# Altium schematic sheet Precise_Resistors.SchDoc
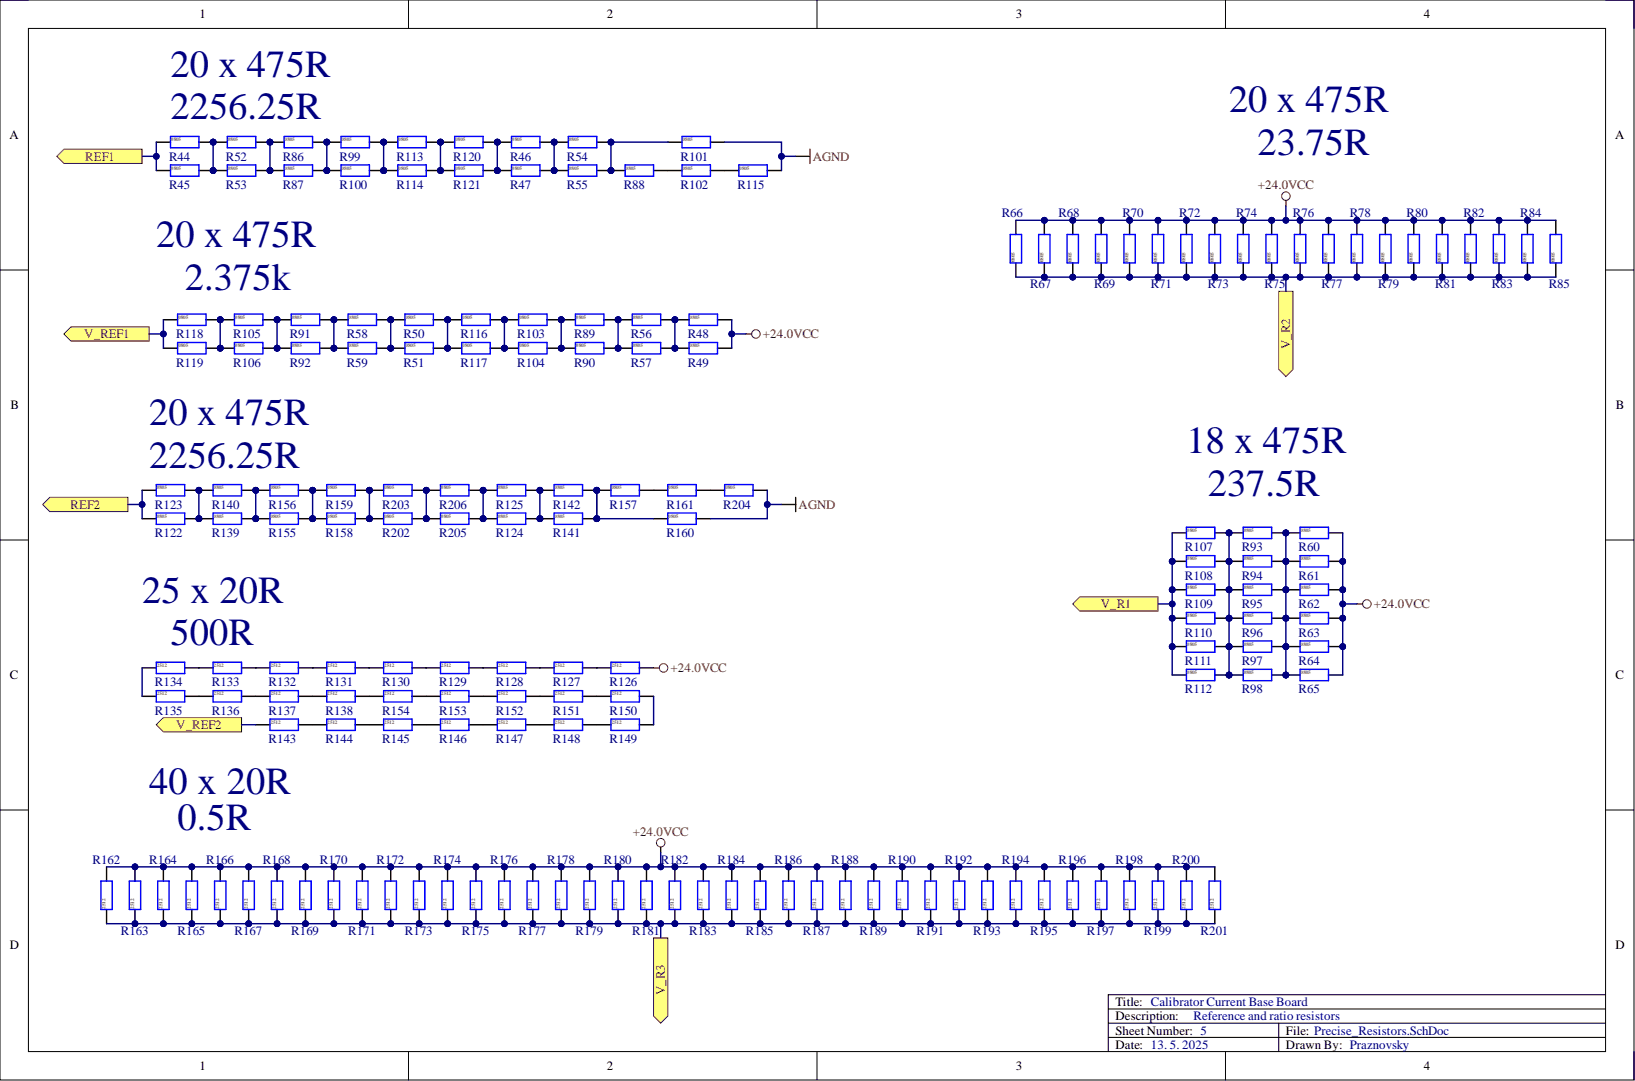
[diagram p0: Precise_Resistors.SchDoc]

## Parts
R44  Y4022475R000F9R  [R0805]  (value not drawn)
R45  Y4022475R000F9R  [R0805]  (value not drawn)
R46  Y4022475R000F9R  [R0805]  (value not drawn)
R47  Y4022475R000F9R  [R0805]  (value not drawn)
R48  Y4022475R000F9R  [R0805]  (value not drawn)
R49  Y4022475R000F9R  [R0805]  (value not drawn)
R50  Y4022475R000F9R  [R0805]  (value not drawn)
R51  Y4022475R000F9R  [R0805]  (value not drawn)
R52  Y4022475R000F9R  [R0805]  (value not drawn)
R53  Y4022475R000F9R  [R0805]  (value not drawn)
R54  Y4022475R000F9R  [R0805]  (value not drawn)
R55  Y4022475R000F9R  [R0805]  (value not drawn)
R56  Y4022475R000F9R  [R0805]  (value not drawn)
R57  Y4022475R000F9R  [R0805]  (value not drawn)
R58  Y4022475R000F9R  [R0805]  (value not drawn)
R59  Y4022475R000F9R  [R0805]  (value not drawn)
R60  Y4022475R000F9R  [R0805]  (value not drawn)
R61  Y4022475R000F9R  [R0805]  (value not drawn)
R62  Y4022475R000F9R  [R0805]  (value not drawn)
R63  Y4022475R000F9R  [R0805]  (value not drawn)
R64  Y4022475R000F9R  [R0805]  (value not drawn)
R65  Y4022475R000F9R  [R0805]  (value not drawn)
R66  Y4022475R000F9R  [R0805]  (value not drawn)
R67  Y4022475R000F9R  [R0805]  (value not drawn)
R68  Y4022475R000F9R  [R0805]  (value not drawn)
R69  Y4022475R000F9R  [R0805]  (value not drawn)
R70  Y4022475R000F9R  [R0805]  (value not drawn)
R71  Y4022475R000F9R  [R0805]  (value not drawn)
R72  Y4022475R000F9R  [R0805]  (value not drawn)
R73  Y4022475R000F9R  [R0805]  (value not drawn)
R74  Y4022475R000F9R  [R0805]  (value not drawn)
R75  Y4022475R000F9R  [R0805]  (value not drawn)
R76  Y4022475R000F9R  [R0805]  (value not drawn)
R77  Y4022475R000F9R  [R0805]  (value not drawn)
R78  Y4022475R000F9R  [R0805]  (value not drawn)
R79  Y4022475R000F9R  [R0805]  (value not drawn)
R80  Y4022475R000F9R  [R0805]  (value not drawn)
R81  Y4022475R000F9R  [R0805]  (value not drawn)
R82  Y4022475R000F9R  [R0805]  (value not drawn)
R83  Y4022475R000F9R  [R0805]  (value not drawn)
R84  Y4022475R000F9R  [R0805]  (value not drawn)
R85  Y4022475R000F9R  [R0805]  (value not drawn)
R86  Y4022475R000F9R  [R0805]  (value not drawn)
R87  Y4022475R000F9R  [R0805]  (value not drawn)
R88  Y4022475R000F9R  [R0805]  (value not drawn)
R89  Y4022475R000F9R  [R0805]  (value not drawn)
R90  Y4022475R000F9R  [R0805]  (value not drawn)
R91  Y4022475R000F9R  [R0805]  (value not drawn)
R92  Y4022475R000F9R  [R0805]  (value not drawn)
R93  Y4022475R000F9R  [R0805]  (value not drawn)
R94  Y4022475R000F9R  [R0805]  (value not drawn)
R95  Y4022475R000F9R  [R0805]  (value not drawn)
R96  Y4022475R000F9R  [R0805]  (value not drawn)
R97  Y4022475R000F9R  [R0805]  (value not drawn)
R98  Y4022475R000F9R  [R0805]  (value not drawn)
R99  Y4022475R000F9R  [R0805]  (value not drawn)
R100  Y4022475R000F9R  [R0805]  (value not drawn)
R101  Y4022475R000F9R  [R0805]  (value not drawn)
R102  Y4022475R000F9R  [R0805]  (value not drawn)
R103  Y4022475R000F9R  [R0805]  (value not drawn)
R104  Y4022475R000F9R  [R0805]  (value not drawn)
R105  Y4022475R000F9R  [R0805]  (value not drawn)
R106  Y4022475R000F9R  [R0805]  (value not drawn)
R107  Y4022475R000F9R  [R0805]  (value not drawn)
R108  Y4022475R000F9R  [R0805]  (value not drawn)
R109  Y4022475R000F9R  [R0805]  (value not drawn)
R110  Y4022475R000F9R  [R0805]  (value not drawn)
R111  Y4022475R000F9R  [R0805]  (value not drawn)
R112  Y4022475R000F9R  [R0805]  (value not drawn)
R113  Y4022475R000F9R  [R0805]  (value not drawn)
R114  Y4022475R000F9R  [R0805]  (value not drawn)
R115  Y4022475R000F9R  [R0805]  (value not drawn)
R116  Y4022475R000F9R  [R0805]  (value not drawn)
R117  Y4022475R000F9R  [R0805]  (value not drawn)
R118  Y4022475R000F9R  [R0805]  (value not drawn)
R119  Y4022475R000F9R  [R0805]  (value not drawn)
R120  Y4022475R000F9R  [R0805]  (value not drawn)
R121  Y4022475R000F9R  [R0805]  (value not drawn)
R122  Y4022475R000F9R  [R0805]  (value not drawn)
R123  Y4022475R000F9R  [R0805]  (value not drawn)
R124  Y4022475R000F9R  [R0805]  (value not drawn)
R125  Y4022475R000F9R  [R0805]  (value not drawn)
R126  Y402720R0000C9R  [R2512]  (value not drawn)
R127  Y402720R0000C9R  [R2512]  (value not drawn)
R128  Y402720R0000C9R  [R2512]  (value not drawn)
R129  Y402720R0000C9R  [R2512]  (value not drawn)
R130  Y402720R0000C9R  [R2512]  (value not drawn)
R131  Y402720R0000C9R  [R2512]  (value not drawn)
R132  Y402720R0000C9R  [R2512]  (value not drawn)
R133  Y402720R0000C9R  [R2512]  (value not drawn)
R134  Y402720R0000C9R  [R2512]  (value not drawn)
R135  Y402720R0000C9R  [R2512]  (value not drawn)
R136  Y402720R0000C9R  [R2512]  (value not drawn)
R137  Y402720R0000C9R  [R2512]  (value not drawn)
R138  Y402720R0000C9R  [R2512]  (value not drawn)
R139  Y4022475R000F9R  [R0805]  (value not drawn)
R140  Y4022475R000F9R  [R0805]  (value not drawn)
R141  Y4022475R000F9R  [R0805]  (value not drawn)
R142  Y4022475R000F9R  [R0805]  (value not drawn)
R143  Y402720R0000C9R  [R2512]  (value not drawn)
R144  Y402720R0000C9R  [R2512]  (value not drawn)
R145  Y402720R0000C9R  [R2512]  (value not drawn)
R146  Y402720R0000C9R  [R2512]  (value not drawn)
R147  Y402720R0000C9R  [R2512]  (value not drawn)
R148  Y402720R0000C9R  [R2512]  (value not drawn)
R149  Y402720R0000C9R  [R2512]  (value not drawn)
R150  Y402720R0000C9R  [R2512]  (value not drawn)
R151  Y402720R0000C9R  [R2512]  (value not drawn)
R152  Y402720R0000C9R  [R2512]  (value not drawn)
R153  Y402720R0000C9R  [R2512]  (value not drawn)
R154  Y402720R0000C9R  [R2512]  (value not drawn)
R155  Y4022475R000F9R  [R0805]  (value not drawn)
R156  Y4022475R000F9R  [R0805]  (value not drawn)
R157  Y4022475R000F9R  [R0805]  (value not drawn)
R158  Y4022475R000F9R  [R0805]  (value not drawn)
R159  Y4022475R000F9R  [R0805]  (value not drawn)
R160  Y4022475R000F9R  [R0805]  (value not drawn)
R161  Y4022475R000F9R  [R0805]  (value not drawn)
R162  Y402720R0000C9R  [R2512]  (value not drawn)
R163  Y402720R0000C9R  [R2512]  (value not drawn)
R164  Y402720R0000C9R  [R2512]  (value not drawn)
R165  Y402720R0000C9R  [R2512]  (value not drawn)
R166  Y402720R0000C9R  [R2512]  (value not drawn)
R167  Y402720R0000C9R  [R2512]  (value not drawn)
R168  Y402720R0000C9R  [R2512]  (value not drawn)
R169  Y402720R0000C9R  [R2512]  (value not drawn)
R170  Y402720R0000C9R  [R2512]  (value not drawn)
R171  Y402720R0000C9R  [R2512]  (value not drawn)
R172  Y402720R0000C9R  [R2512]  (value not drawn)
R173  Y402720R0000C9R  [R2512]  (value not drawn)
R174  Y402720R0000C9R  [R2512]  (value not drawn)
R175  Y402720R0000C9R  [R2512]  (value not drawn)
R176  Y402720R0000C9R  [R2512]  (value not drawn)
R177  Y402720R0000C9R  [R2512]  (value not drawn)
R178  Y402720R0000C9R  [R2512]  (value not drawn)
R179  Y402720R0000C9R  [R2512]  (value not drawn)
R180  Y402720R0000C9R  [R2512]  (value not drawn)
R181  Y402720R0000C9R  [R2512]  (value not drawn)
R182  Y402720R0000C9R  [R2512]  (value not drawn)
R183  Y402720R0000C9R  [R2512]  (value not drawn)
R184  Y402720R0000C9R  [R2512]  (value not drawn)
R185  Y402720R0000C9R  [R2512]  (value not drawn)
R186  Y402720R0000C9R  [R2512]  (value not drawn)
R187  Y402720R0000C9R  [R2512]  (value not drawn)
R188  Y402720R0000C9R  [R2512]  (value not drawn)
R189  Y402720R0000C9R  [R2512]  (value not drawn)
R190  Y402720R0000C9R  [R2512]  (value not drawn)
R191  Y402720R0000C9R  [R2512]  (value not drawn)
R192  Y402720R0000C9R  [R2512]  (value not drawn)
R193  Y402720R0000C9R  [R2512]  (value not drawn)
R194  Y402720R0000C9R  [R2512]  (value not drawn)
R195  Y402720R0000C9R  [R2512]  (value not drawn)
R196  Y402720R0000C9R  [R2512]  (value not drawn)
R197  Y402720R0000C9R  [R2512]  (value not drawn)
R198  Y402720R0000C9R  [R2512]  (value not drawn)
R199  Y402720R0000C9R  [R2512]  (value not drawn)
R200  Y402720R0000C9R  [R2512]  (value not drawn)
R201  Y402720R0000C9R  [R2512]  (value not drawn)
R202  Y4022475R000F9R  [R0805]  (value not drawn)
R203  Y4022475R000F9R  [R0805]  (value not drawn)
R204  Y4022475R000F9R  [R0805]  (value not drawn)
R205  Y4022475R000F9R  [R0805]  (value not drawn)
R206  Y4022475R000F9R  [R0805]  (value not drawn)

## Ports
REF1, REF2, V_R1, V_R2, V_R3, V_REF1, V_REF2

## Nets
+24.0VCC: R48.2 R49.2 R60.2 R61.2 R62.2 R63.2 R64.2 R65.2 R66.2 R67.2 R68.2 R69.2 R70.2 R71.2 R72.2 R73.2 R74.2 R75.2 R76.2 R77.2 R78.2 R79.2 R80.2 R81.2 R82.2 R83.2 R84.2 R85.2 R126.2 R162.2 R163.2 R164.2 R165.2 R166.2 R167.2 R168.2 R169.2 R170.2 R171.2 R172.2 R173.2 R174.2 R175.2 R176.2 R177.2 R178.2 R179.2 R180.2 R181.2 R182.2 R183.2 R184.2 R185.2 R186.2 R187.2 R188.2 R189.2 R190.2 R191.2 R192.2 R193.2 R194.2 R195.2 R196.2 R197.2 R198.2 R199.2 R200.2 R201.2
AGND: R101.2 R115.2 R160.2 R204.2
REF1: R44.1 R45.1 ; port REF1
REF2: R122.1 R123.1 ; port REF2
V_R1: R107.1 R108.1 R109.1 R110.1 R111.1 R112.1 ; port V_R1
V_R2: R66.1 R67.1 R68.1 R69.1 R70.1 R71.1 R72.1 R73.1 R74.1 R75.1 R76.1 R77.1 R78.1 R79.1 R80.1 R81.1 R82.1 R83.1 R84.1 R85.1 ; port V_R2
V_R3: R162.1 R163.1 R164.1 R165.1 R166.1 R167.1 R168.1 R169.1 R170.1 R171.1 R172.1 R173.1 R174.1 R175.1 R176.1 R177.1 R178.1 R179.1 R180.1 R181.1 R182.1 R183.1 R184.1 R185.1 R186.1 R187.1 R188.1 R189.1 R190.1 R191.1 R192.1 R193.1 R194.1 R195.1 R196.1 R197.1 R198.1 R199.1 R200.1 R201.1 ; port V_R3
V_REF1: R118.1 R119.1 ; port V_REF1
V_REF2: R143.1 ; port V_REF2
NetR44_2: R44.2 R45.2 R52.1 R53.1
NetR46_1: R46.1 R47.1 R120.2 R121.2
NetR46_2: R46.2 R47.2 R54.1 R55.1
NetR48_1: R48.1 R49.1 R56.2 R57.2
NetR50_1: R50.1 R51.1 R58.2 R59.2
NetR50_2: R50.2 R51.2 R116.1 R117.1
NetR52_2: R52.2 R53.2 R86.1 R87.1
NetR54_2: R54.2 R55.2 R88.1 R101.1
NetR56_1: R56.1 R57.1 R89.2 R90.2
NetR58_1: R58.1 R59.1 R91.2 R92.2
NetR60_1: R60.1 R61.1 R62.1 R63.1 R64.1 R65.1 R93.2 R94.2 R95.2 R96.2 R97.2 R98.2
NetR86_2: R86.2 R87.2 R99.1 R100.1
NetR88_2: R88.2 R102.1
NetR89_1: R89.1 R90.1 R103.2 R104.2
NetR91_1: R91.1 R92.1 R105.2 R106.2
NetR93_1: R93.1 R94.1 R95.1 R96.1 R97.1 R98.1 R107.2 R108.2 R109.2 R110.2 R111.2 R112.2
NetR99_2: R99.2 R100.2 R113.1 R114.1
NetR102_2: R102.2 R115.1
NetR103_1: R103.1 R104.1 R116.2 R117.2
NetR105_1: R105.1 R106.1 R118.2 R119.2
NetR113_2: R113.2 R114.2 R120.1 R121.1
NetR122_2: R122.2 R123.2 R139.1 R140.1
NetR124_1: R124.1 R125.1 R205.2 R206.2
NetR124_2: R124.2 R125.2 R141.1 R142.1
NetR126_1: R126.1 R127.2
NetR127_1: R127.1 R128.2
NetR128_1: R128.1 R129.2
NetR129_1: R129.1 R130.2
NetR130_1: R130.1 R131.2
NetR131_1: R131.1 R132.2
NetR132_1: R132.1 R133.2
NetR133_1: R133.1 R134.2
NetR134_1: R134.1 R135.1
NetR135_2: R135.2 R136.1
NetR136_2: R136.2 R137.1
NetR137_2: R137.2 R138.1
NetR138_2: R138.2 R154.1
NetR139_2: R139.2 R140.2 R155.1 R156.1
NetR141_2: R141.2 R142.2 R157.1 R160.1
NetR143_2: R143.2 R144.1
NetR144_2: R144.2 R145.1
NetR145_2: R145.2 R146.1
NetR146_2: R146.2 R147.1
NetR147_2: R147.2 R148.1
NetR148_2: R148.2 R149.1
NetR149_2: R149.2 R150.2
NetR150_1: R150.1 R151.2
NetR151_1: R151.1 R152.2
NetR152_1: R152.1 R153.2
NetR153_1: R153.1 R154.2
NetR155_2: R155.2 R156.2 R158.1 R159.1
NetR157_2: R157.2 R161.1
NetR158_2: R158.2 R159.2 R202.1 R203.1
NetR161_2: R161.2 R204.1
NetR202_2: R202.2 R203.2 R205.1 R206.1

## Library data of the parts
R44-R125 R139-R142 R155-R161 R202-R206 = CMP-00063-4: Resistor 0805; Component Kind: Standard; Library Name: Calibrator
R126-R138 R143-R154 R162-R201 = CMP-00065-4: Resistor 2512; Component Kind: Standard; Library Name: Calibrator
